# Altium schematic sheet POWER&UART.SchDoc(POWER&UART)
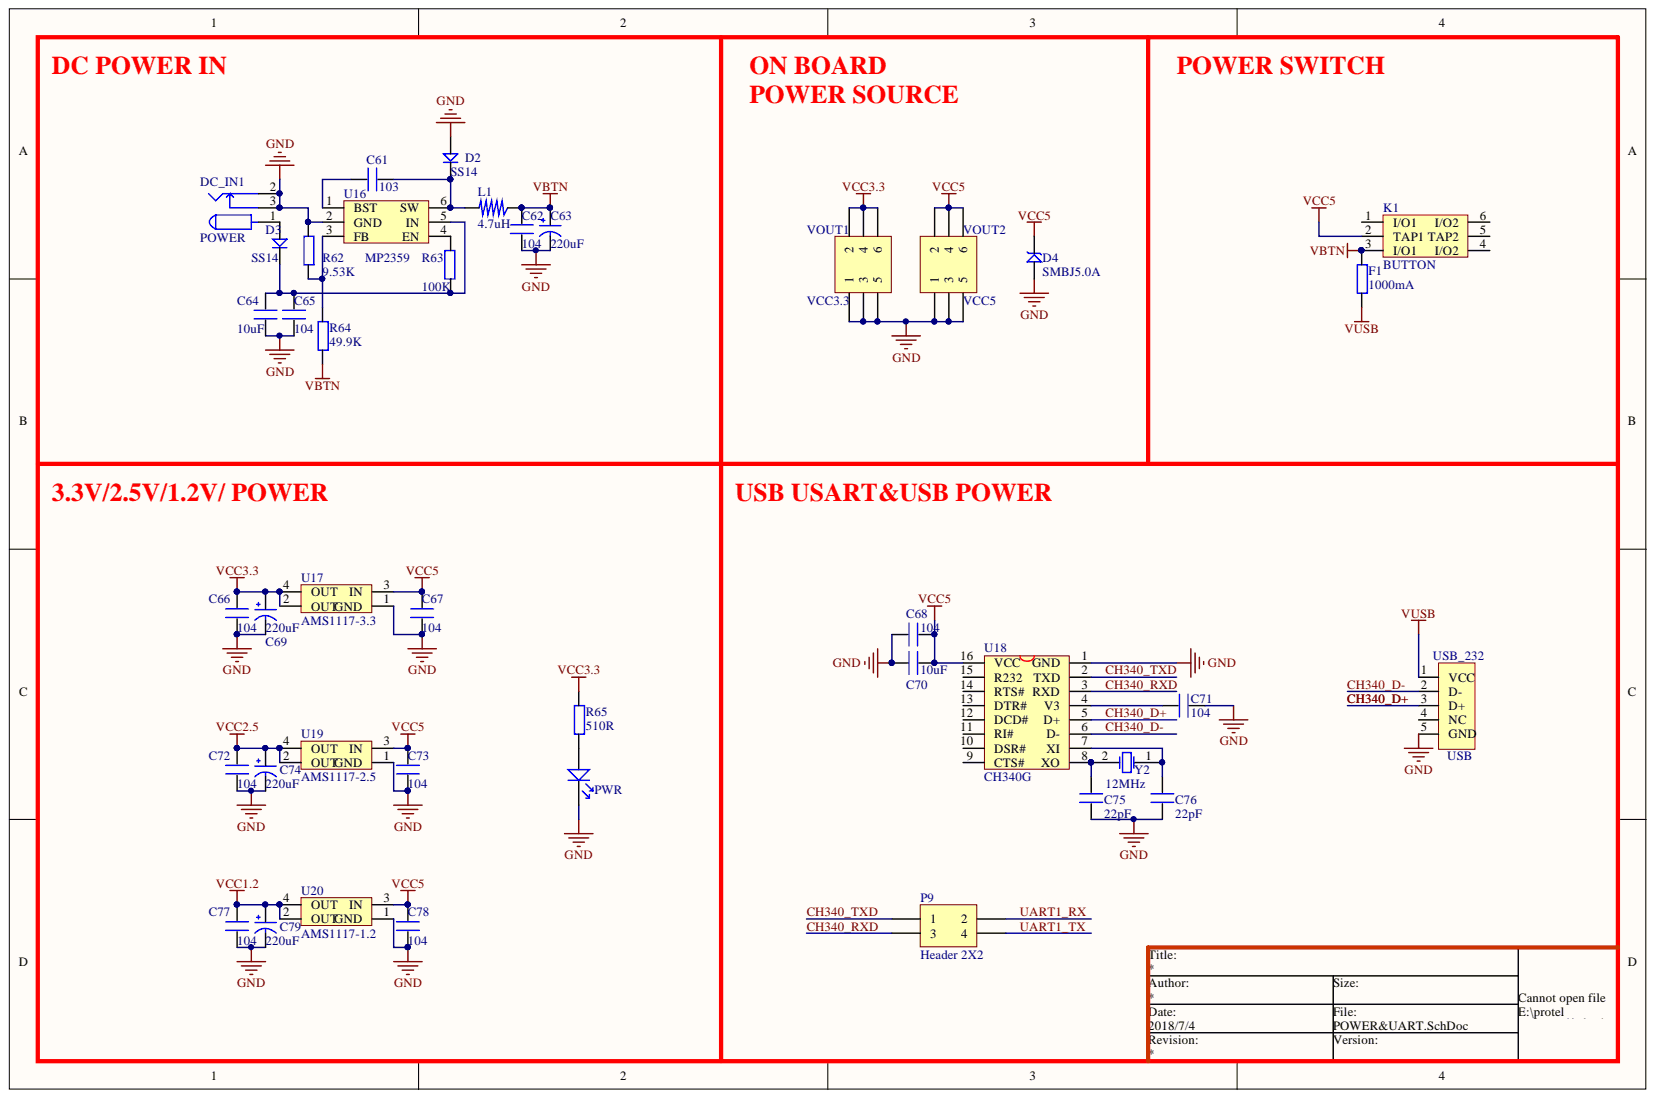
[diagram p0: POWER&UART.SchDoc]

## Parts
C61  103  [0603P]
C62  104  [0603P]
C63  220uF  [CC6.56.5]
C64  10uF  [1206]
C65  104  [0603P]
C66  104  [0603P]
C67  104  [0603P]
C68  104  [0603P]
C69  220uF  [CC6.56.5]
C70  10uF  [0805]
C71  104  [0603P]
C72  104  [0603P]
C73  104  [0603P]
C74  220uF  [CC6.56.5]
C75  22pF  [0603P]
C76  22pF  [0603P]
C77  104  [0603P]
C78  104  [0603P]
C79  220uF  [CC6.56.5]
D2  SS14  [DIODE_3*5.3]
D3  SS14  [DIODE_3*5.3]
D4  SMBJ5.0A  [SMBJ]
DC_IN1  POWER  [DC10B]
F1  1000mA  [0805]
K1  BUTTON  [BTN8.5*8.5]
L1  4.7uH  [L_SOP74]
LED5  PWR  [SMDLED-0805]
P9  Header 2X2  [HDR2X2]
R62  9.53K  [0603P]
R63  100K  [0603P]
R64  49.9K  [0603P]
R65  510R  [0603P]
U16  MP2359  [SOT-23-6]
U17  AMS1117-3.3  [AMS1117]
U18  CH340G  [SOP16M]
U19  AMS1117-2.5  [AMS1117]
U20  AMS1117-1.2  [AMS1117]
USB_232  USB  [USB/SM0.8-6H5]
VOUT1  VCC3.3  [HDR2X3]
VOUT2  VCC5  [HDR2X3]
Y2  12MHz  [XTAL_US]

## Nets
GND: C62.1 C63.2 C64.1 C65.2 C66.1 C67.1 C68.1 C69.2 C70.2 C71.2 C72.1 C73.1 C74.2 C75.1 C76.1 C77.1 C78.1 C79.2 D2.1 D4.1 DC_IN1.2 DC_IN1.3 LED5.2 R62.2 U16.2(GND) U17.1(GND) U18.1(GND) U19.1(GND) U20.1(GND) USB_232.5(GND) VOUT1.1 VOUT1.3 VOUT1.5 VOUT2.1 VOUT2.3 VOUT2.5
VBTN: C62.2 C63.1 F1.2 K1.3(I/O1) L1.1 R64.1
VCC1.2: C77.2 C79.1 U20.2(OUT) U20.4(OUT)
VCC2.5: C72.2 C74.1 U19.2(OUT) U19.4(OUT)
VCC3.3: C66.2 C69.1 R65.2 U17.2(OUT) U17.4(OUT) VOUT1.2 VOUT1.4 VOUT1.6
VCC5: C67.2 C68.2 C70.1 C73.2 C78.2 D4.2 K1.2(TAP1) U17.3(IN) U18.16(VCC) U19.3(IN) U20.3(IN) VOUT2.2 VOUT2.4 VOUT2.6
VUSB: F1.1 USB_232.1(VCC)
CH340_D+: U18.5(D+) USB_232.3(D+)
CH340_D-: U18.6(D-) USB_232.2(D-)
CH340_RXD: P9.3 U18.3(RXD)
CH340_TXD: P9.1 U18.2(TXD)
UART1_RX: P9.2
UART1_TX: P9.4
NetC61_1: C61.1 U16.1(BST)
NetC61_2: C61.2 D2.2 L1.2 U16.6(SW)
NetC64_2: C64.2 C65.1 D3.2 R63.2 U16.5(IN)
NetC71_1: C71.1 U18.4(V3)
NetC75_2: C75.2 U18.8(XO) Y2.2
NetC76_2: C76.2 U18.7(XI) Y2.1
NetD3_1: D3.1 DC_IN1.1
NetLED5_1: LED5.1 R65.1
NetR62_1: R62.1 R64.2 U16.3(FB)
NetR63_1: R63.1 U16.4(EN)

Not connected: K1 1(I/O1) 4(I/O2) 5(TAP2) 6(I/O2); U18 9(CTS#) 10(DSR#) 11(RI#) 12(DCD#) 13(DTR#) 14(RTS#) 15(R232); USB_232 4(NC)

## Library data of the parts
C61 C62 C64-C68 C70-C73 C75-C78 = C: Component Kind: Standard
  C61 C64: Library Name: ALIENTEK_COMPONENT.IntLib
  C62 C65-C68 C70-C73 C75-C78: Library Name: MYCOMLIB.IntLib
C63 C69 C74 C79 = CAP: Library Name: MYCOMLIB.IntLib; Component Kind: Standard
D2 D3 = D: 1N4148; Library Name: MYCOMLIB.IntLib; Component Kind: Standard
D4 = SMBJ: TVS; Library Name: ALIENTEK_COMPONENT.IntLib; Component Kind: Standard
DC_IN1 = POW: Library Name: ALIENTEK_COMPONENT.IntLib; Component Kind: Standard
F1 R62-R65 = R: Component Kind: Standard
  F1 R65: Library Name: MYCOMLIB.IntLib
  R62-R64: Library Name: ALIENTEK_COMPONENT.IntLib
L1 = L_SOP: Library Name: ALIENTEK_COMPONENT.IntLib; Component Kind: Standard
LED5 = LED: Library Name: ALIENTEK_COMPONENT.IntLib; Component Kind: Standard
P9 = Header 2X2: Header, 2-Pin, Dual row; Signal Integrity: Connector; Library Name: Miscellaneous Connectors.IntLib; LatestRevisionNote: Re-released for DXP Platform.; LatestRevisionDate: 17-Jul-2002; Component Kind: Standard; Publisher: Altium Limited
U16 = MP2359: DC DC Step Down IC; Library Name: ALIENTEK_COMPONENT.IntLib; Component Kind: Standard
U17 U19 U20 = AMS1117: Library Name: MYCOMLIB.IntLib; Component Kind: Standard
U18 = CH340G: USB2UART; Library Name: ALIENTEK_COMPONENT.IntLib; Component Kind: Standard
USB_232 = USB5: Library Name: MYCOMLIB.IntLib; Component Kind: Standard
VOUT1 VOUT2 = Header 3X2: Header, 3-Pin, Dual row; Library Name: Miscellaneous Connectors.IntLib; LatestRevisionNote: Re-released for DXP Platform.; LatestRevisionDate: 17-Jul-2002; Component Kind: Standard; Publisher: Altium Limited
Y2 = XTAL: Crystal Oscillator; Simulation: XTAL; Published: 8-Jun-2000; Library Name: Miscellaneous Devices.IntLib; LatestRevisionNote: Re-released for DXP Platform.; LatestRevisionDate: 17-Jul-2002; Component Kind: Standard; Publisher: Altium Limited
K1 = BUTTON: Library Name: ALIENTEK_COMPONENT.IntLib; Component Kind: Standard
